# Altium schematic sheet fpc.SchDoc(fpc)
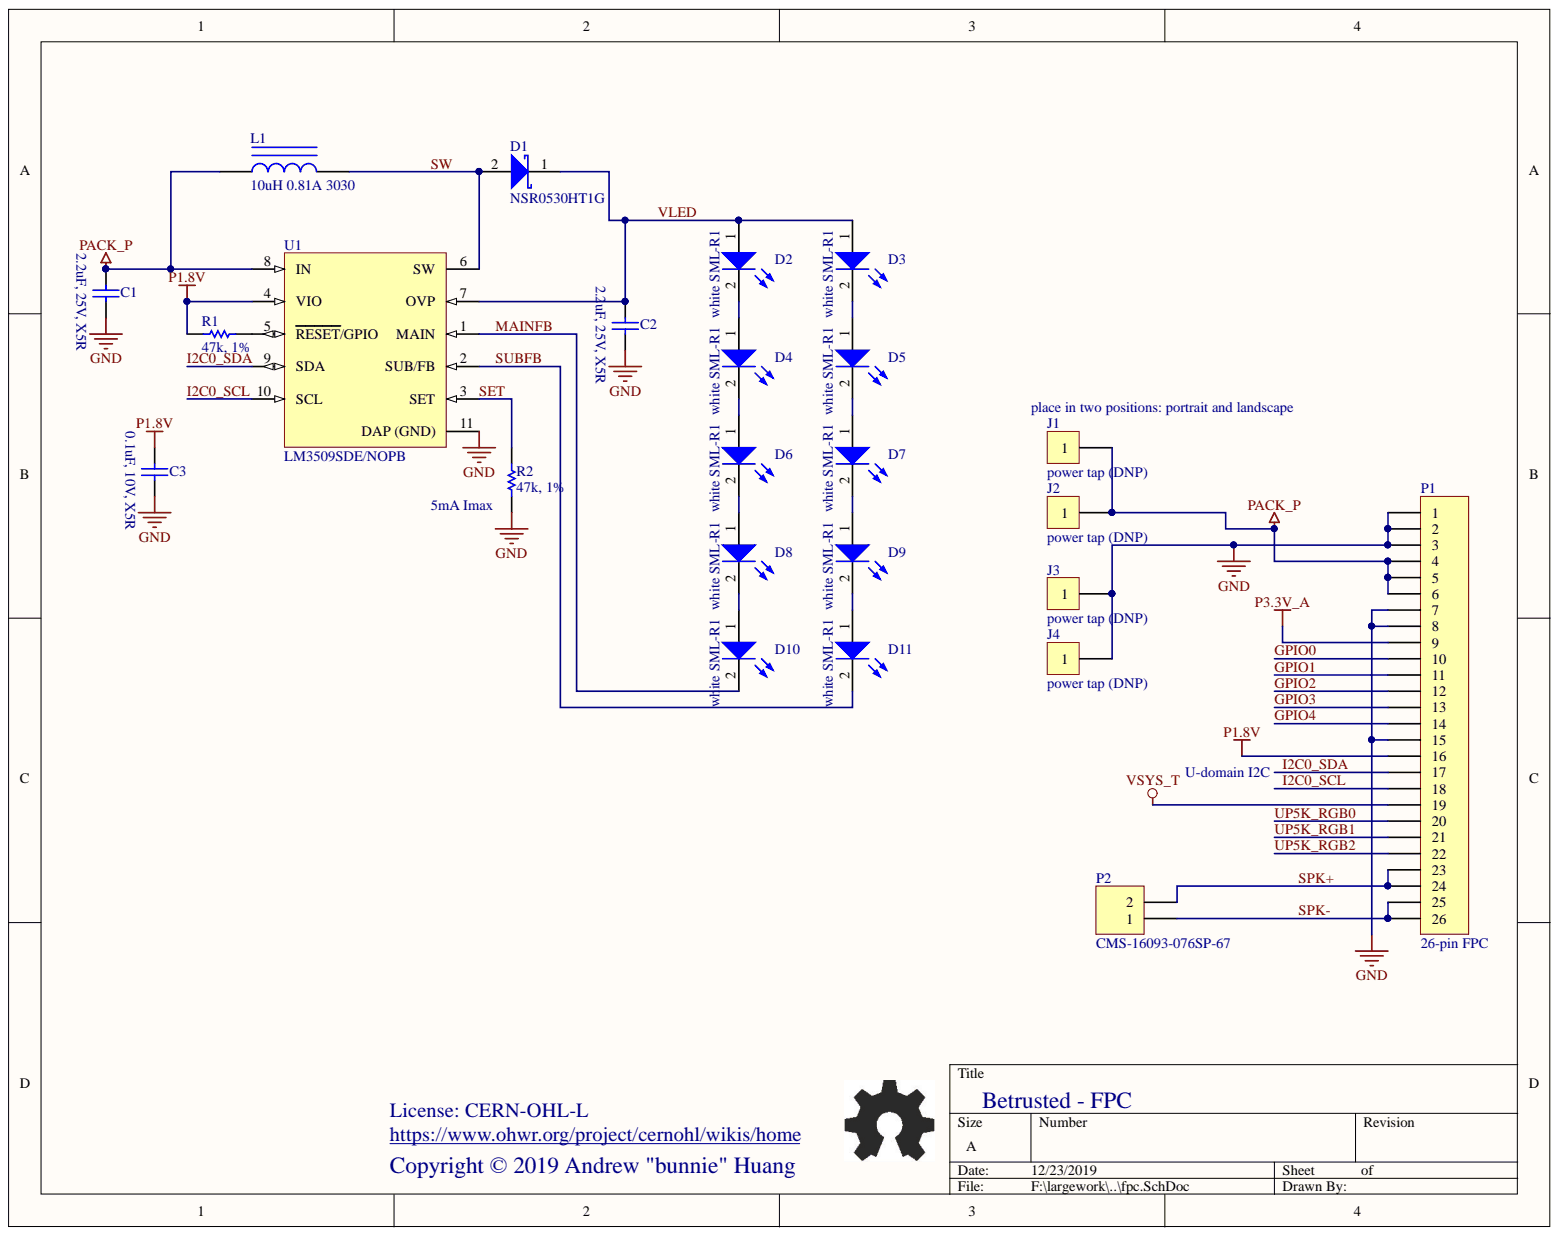
[diagram p0: fpc.SchDoc]

## Parts
C1  2.2uF, 25V, X5R  [B_CAP0603]
C2  2.2uF, 25V, X5R  [B_CAP0603]
C3  0.1uF, 10V, X5R  [B_CAP0402]
D1  NSR0530HT1G  [SOD-323]
D2  white SML-R1  [ROHM_SML-R1]
D3  white SML-R1  [ROHM_SML-R1]
D4  white SML-R1  [ROHM_SML-R1]
D5  white SML-R1  [ROHM_SML-R1]
D6  white SML-R1  [ROHM_SML-R1]
D7  white SML-R1  [ROHM_SML-R1]
D8  white SML-R1  [ROHM_SML-R1]
D9  white SML-R1  [ROHM_SML-R1]
D10  white SML-R1  [ROHM_SML-R1]
D11  white SML-R1  [ROHM_SML-R1]
J1  power tap (DNP)  [RESTAP-0603]
J2  power tap (DNP)  [RESTAP-0603]
J3  power tap (DNP)  [RESTAP-0603]
J4  power tap (DNP)  [RESTAP-0603]
L1  10uH 0.81A 3030  [B_IND3030_MUR]
P1  26-pin FPC  [HRS_FH34SRJ-26S-FPC]
P2  CMS-16093-076SP-67  [CUI_CMS-16093-076SP-67]
R1  47k, 1%  [B_RES0402]
R2  47k, 1%  [B_RES0402]
U1  LM3509SDE/NOPB  [DSC0010A_V]

## Nets
GND: C1.2 C2.2 C3.2 J3.1 J4.1 P1.1 P1.2 P1.3 P1.7 P1.8 P1.15 R2.2 U1.11(DAP_(GND))
P1.8V: C3.1 P1.16 R1.1 U1.4(VIO)
P3.3V_A: P1.9
PACK_P: C1.1 J1.1 J2.1 L1.1 P1.4 P1.5 P1.6 U1.8(IN)
VSYS_T: P1.19
GPIO0: P1.10
GPIO1: P1.11
GPIO2: P1.12
GPIO3: P1.13
GPIO4: P1.14
I2C0_SCL: P1.18 U1.10(SCL)
I2C0_SDA: P1.17 U1.9(SDA)
MAINFB: D10.2 U1.1(MAIN)
SET: R2.1 U1.3(SET)
SPK+: P1.23 P1.24 P2.2
SPK-: P1.25 P1.26 P2.1
SUBFB: D11.2 U1.2(SUB/FB)
SW: D1.2 L1.2 U1.6(SW)
UP5K_RGB0: P1.20
UP5K_RGB1: P1.21
UP5K_RGB2: P1.22
VLED: C2.1 D1.1 D2.1 D3.1 U1.7(OVP)
NetD2_2: D2.2 D4.1
NetD3_2: D3.2 D5.1
NetD4_2: D4.2 D6.1
NetD5_2: D5.2 D7.1
NetD6_2: D6.2 D8.1
NetD7_2: D7.2 D9.1
NetD8_2: D8.2 D10.1
NetD9_2: D9.2 D11.1
NetR1_2: R1.2 U1.5(RESET/GPIO)

## Library data of the parts
C1 C2 = Cap Semi: Capacitor (Semiconductor SIM Model); MPN: GRM188R61E225MA12D; VENDOR: Murata; MYPN: C-225-25-X5R-0603; Simulation: CAP; Signal Integrity: Cap Semi; Library Name: Miscellaneous Devices.IntLib; Component Kind: Standard
C3 = Cap Semi: Capacitor (Semiconductor SIM Model); MPN: CL05A104KP5NNNC; VENDOR: Samsung; MYPN: C-104-10-X5R-0402; Simulation: CAP; Signal Integrity: Cap Semi; Library Name: Miscellaneous Devices.IntLib; Component Kind: Standard
D1 = Diode 11DQ03: Schottky Rectifier; VENDOR: On Semi; MYPN: D-NSR0530HT1G; Simulation: 11DQ03; MPN: NSR0530HT1G; Library Name: Miscellaneous Devices.IntLib; ComponentLink2URL: http://www.irf.com/product-info/datasheets/data/11dq03.pdf; ComponentLink2Description: Datasheet; ComponentLink1URL: http://www.irf.com/; ComponentLink1Description: Manufacturer Link; Component Kind: Standard
D2-D11 = LED2: Red LED; MPN: SMLR13WBDW; VENDOR: ROHM; MYPN: D-LED-WHT-SIDE-0.2mm; Simulation: LED2; Published: 8-Jun-2000; PackageVersion: Feb-2002; PackageReference: 3.2X1.6X1.1; Library Name: Miscellaneous Devices.IntLib; LatestRevisionNote: Re-released for DXP Platform.; LatestRevisionDate: 17-Jul-2002; Component Kind: Standard; Publisher: Altium Limited
J1-J4 = CON1: Connector; MYPN: J-TP-PWRTAP-0603; VENDOR: DNP; MPN: DNP; Component Kind: Standard
L1 = Inductor Iron: Magnetic-Core Inductor; MPN: LQH3NPN100MJRL; VENDOR: Murata; MYPN: L-LQH3NPN100MJRL; Simulation: INDUCTOR; Signal Integrity: Inductor Iron; Library Name: Miscellaneous Devices.IntLib; Component Kind: Standard
P1 = FPC-26: 26 Pin FPC footprint; VENDOR: FPC pattern; System: Connector; MYPN: DNP; MPN: DNP; Library Name: commonsch-new.SchLib; Component Kind: Standard
P2 = Header 2: VENDOR: CUI; MYPN: SPK-CMS-16093-076SP-67; Signal Integrity: Connector; MPN: CMS-16093-076SP-67; Library Name: Miscellaneous Connectors.IntLib; LatestRevisionNote: Re-released for DXP Platform.; LatestRevisionDate: 17-Jul-2002; Description: CMS-16093-076SP-67; Component Kind: Standard; Publisher: Altium Limited
R1 R2 = Res1: Resistor; VENDOR: ANY; MYPN: R-473-1P-1_16W-0402; Simulation: RESISTOR; Signal Integrity: Res; MPN: ANY; Library Name: Miscellaneous Devices.IntLib; Component Kind: Standard
U1 = LM3509SDE/NOPB: VENDOR: TI; MYPN: U-LM3509SDE/NOPB; MPN: LM3509SDE/NOPB; Library Name: commonsch-new.SchLib; Description: LM3509SDE/NOPB; Component Kind: Standard
